# Altium schematic sheet Active BandPass Filter.SchDoc("Active BandPass Filter")
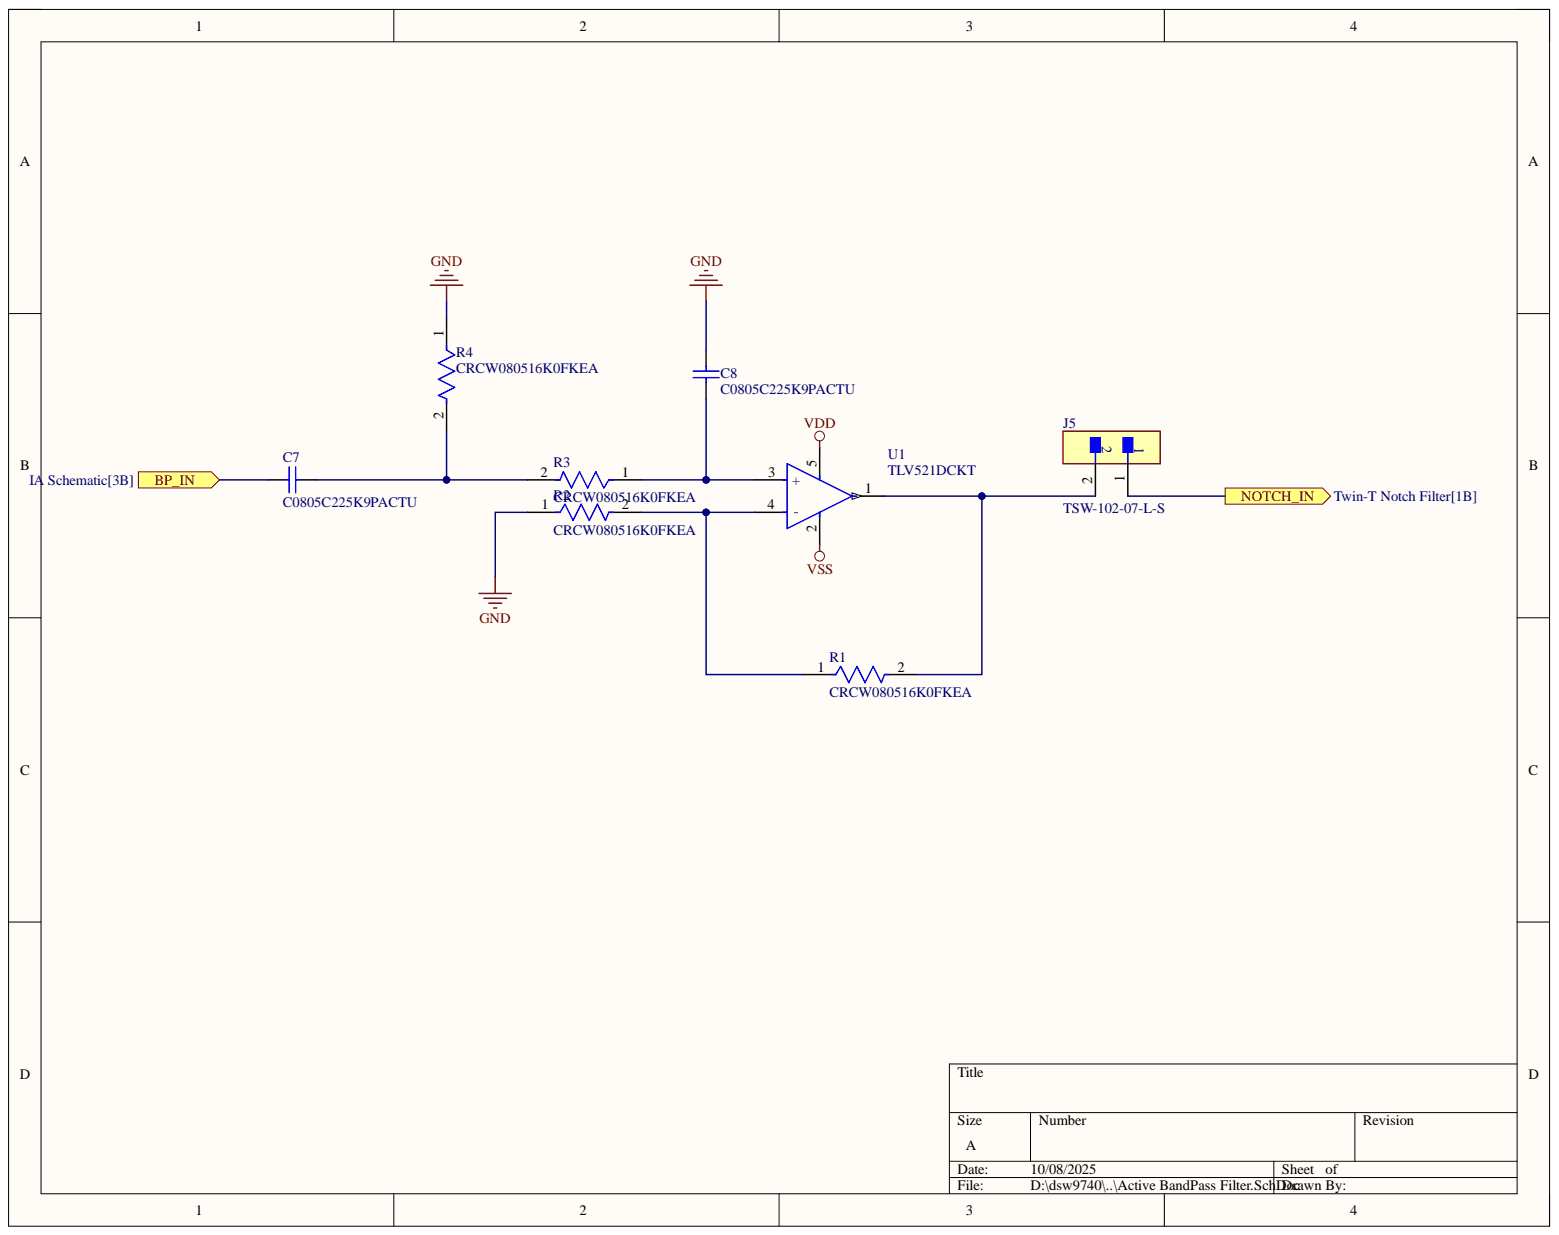
[diagram p0: Active BandPass Filter.SchDoc]

## Parts
C7  C0805C225K9PACTU  [CAPC2013X145X50ML20T25]
C8  C0805C225K9PACTU  [CAPC2013X145X50ML20T25]
J5  TSW-102-07-L-S  [FP-TSW-102-07-L-S-MFG]
R1  CRCW080516K0FKEA  [FP-CRCW0805-e3-IPC_C]
R2  CRCW080516K0FKEA  [FP-CRCW0805-e3-IPC_C]
R3  CRCW080516K0FKEA  [FP-CRCW0805-e3-IPC_C]
R4  CRCW080516K0FKEA  [FP-CRCW0805-e3-IPC_C]
U1  TLV521DCKT  [FP-DCK0005A-MFG]

## Ports
BP_IN, NOTCH_IN

## Nets
GND: C8.1 R2.1 R4.1
VDD: U1.5
VSS: U1.2
BP_IN: C7.2 ; port BP_IN
NOTCH_IN: J5.1 ; port NOTCH_IN
NetC7_1: C7.1 R3.2 R4.2
NetC8_2: C8.2 R3.1 U1.3(+)
NetJ5_2: J5.2 R1.2 U1.1
NetR1_1: R1.1 R2.2 U1.4(-)

## Library data of the parts
C7 C8 = CMP-1036-04819-2: Manufacturer: Kemet; Component Kind: Standard; Library Name: Altium Content Vault; Part Number: C0805C225K9PACTU
J5 = CMP-02766-001428-1: CONN HEADER VERT 2POS 2.54MM; Connector Type: Male Pin; Current Rating: 7.6A; Gender: Male; Max Voltage Rating (AC): 550V; Number of Contacts: 2; Color: Black; Component Kind: Standard; Contact Material: Bronze; Contact Mating Length: 0.23inch; Contact Plating: Gold; Contact Type: Male Pin; Depth: 2.54mm; Housing Color: Black; Housing Material: Polyester; Lead Free: Lead Free; Length: 5.08mm; Library Name: Altium Content Vault; Max Current Rating: 7.6A; Max Operating Temperature: 125°C; Min Operating Temperature: -55°C; Mount: Through Hole; Number of Positions: 2; Number of Rows: 1; Orientation: Straight; Package Quantity: 1; Packaging: Bulk; Pitch: 2.54mm; Radiation Hardening: No; REACH SVHC: No SVHC; RoHS Compliant: Yes; Series: TSW; Termination: Solder; Voltage Rating: 550V; Width: 2.54mm
R1-R4 = CMP-2001-04979-2: RES Thick Film, 16kΩ, 1%, 0.125W, 100ppm/°C, 0805; Max Power Dissipation: 125mW; Resistance: 16kR; Voltage Rating: 150V; Case Code (Imperial): 0805; Case Code (Metric): 2012; Case/Package: 0805; Component Kind: Standard; Composition: Thick Film; Contact Plating: Tin; Depth: 1.25mm; Features: Automotive AEC-Q200; Height: 0.45mm; Lead Free: Lead Free; Length: 2mm; Library Name: Altium Content Vault; Max Operating Temperature: 155°C; Military Standard: Not; Min Operating Temperature: -55°C; Mount: Surface Mount; Number of Pins: 2; Number of Terminations: 2; Package Quantity: 10; Packaging: Tape and Reel; Power Rating: 125mW; Radiation Hardening: No; Temperature Coefficient: 100ppm/°C; Termination: SMD/SMT; Tolerance: 1%; Voltage Rating (DC): 150V; Weight: 0.000194oz; Width: 1.25mm
U1 = CMP-04895-000072-1: IC CMOS 1 CIRCUIT SC70-5; Bandwidth: 6kHz; Input Bias Current: 1pA; Input Offset Voltage (Vos): 100uV; Number of Amplifiers: 1; Operating Supply Current: 350nA; Output Current per Channel: 12mA; Slew Rate: 2.5V/µs; Case/Package: SC; Component Kind: Standard; Gain Bandwidth Product: 6kHz; Lead Free: Lead Free; Library Name: Altium Content Vault; Max Operating Temperature: 125°C; Min Operating Temperature: -40°C; Mount: Surface Mount; Number of Channels: 1; Number of Circuits: 1; Number of Pins: 5; Packaging: Cut Tape; REACH SVHC: No SVHC
